# Altium schematic sheet Main.SchDoc(Main)
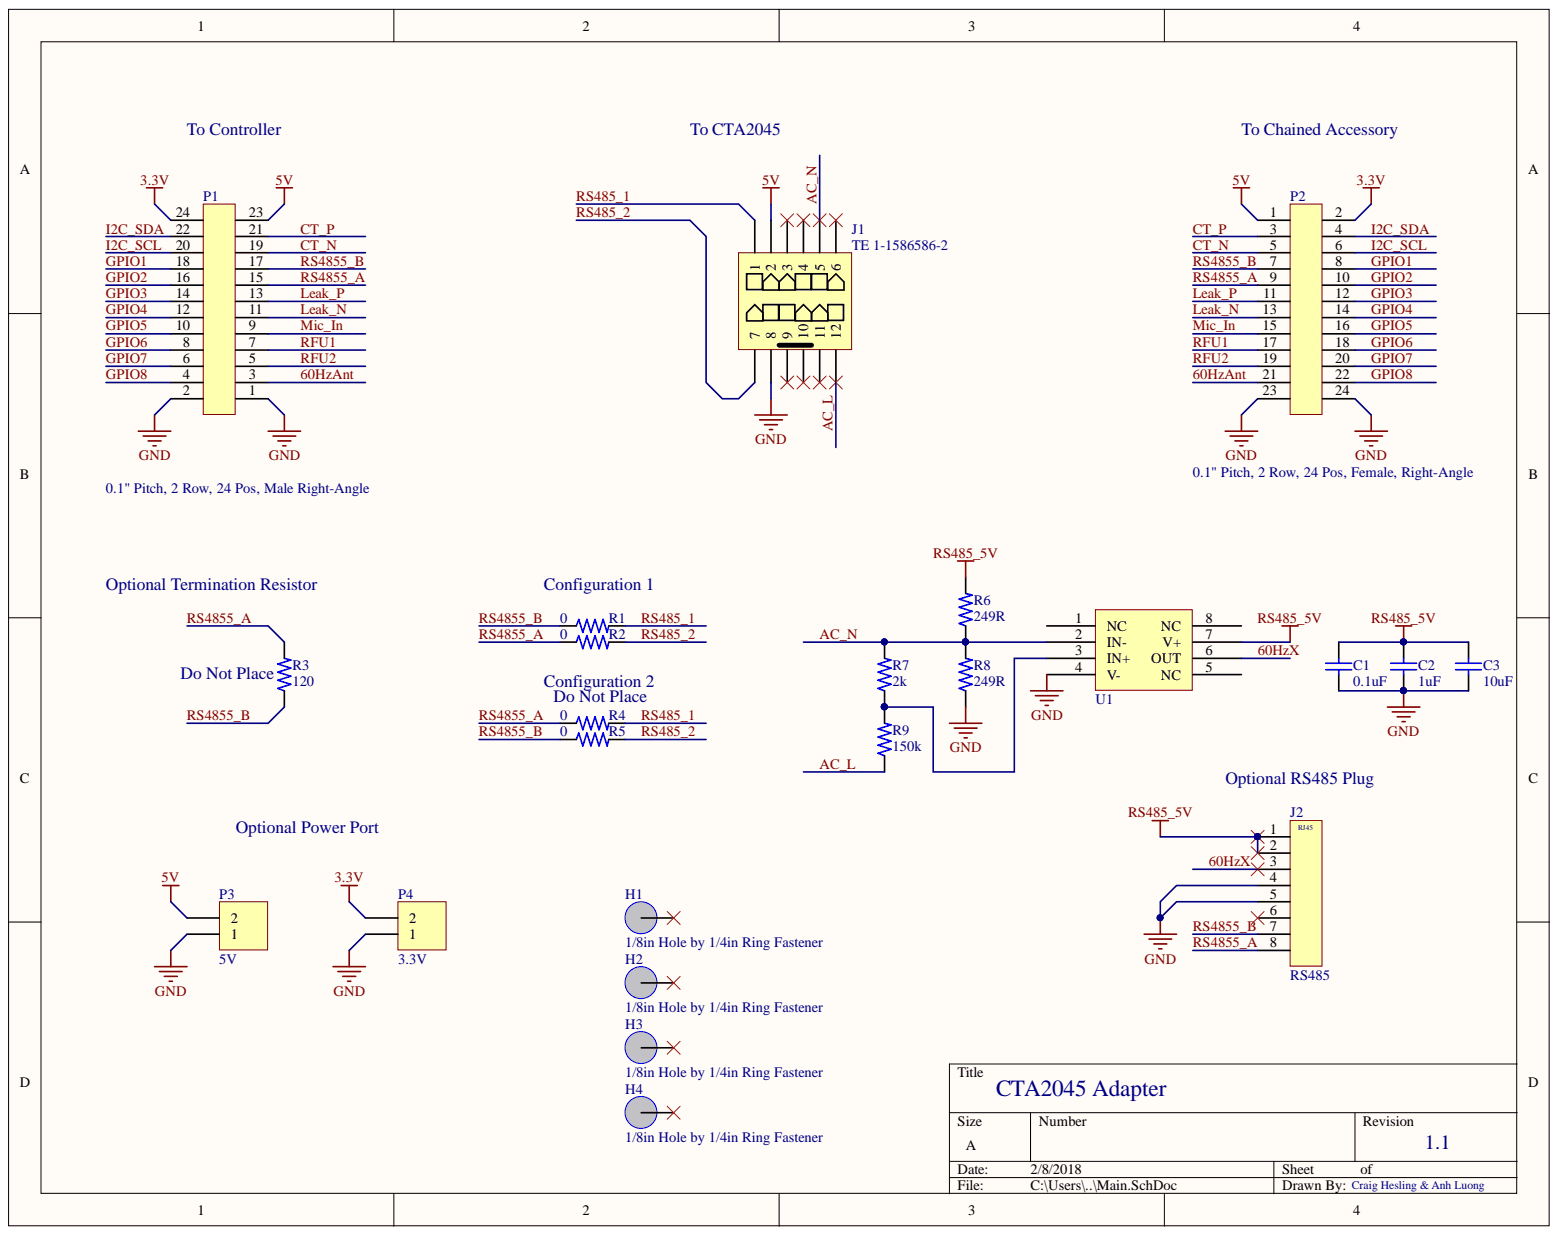
[diagram p0: Main.SchDoc]

## Parts
C1  0.1uF  [CAPC1608X09L - CAP0603]
C2  1uF  [CAPC1608X09L - CAP0603]
C3  10uF  [CAPC1608X09L - CAP0603]
H1  1/8in Hole by 1/4in Ring Fastener  [1/8in Fastener Hole]
H2  1/8in Hole by 1/4in Ring Fastener  [1/8in Fastener Hole]
H3  1/8in Hole by 1/4in Ring Fastener  [1/8in Fastener Hole]
H4  1/8in Hole by 1/4in Ring Fastener  [1/8in Fastener Hole]
J1  TE 1-1586586-2  [12POS 4.2mm Pitch Vertical]
J2  RS485  Connector Type: RJ45  [RJ45 Unshielded PTH Connector]
P1  0.1" Pitch, 2 Row, 24 Pos, Male Right-Angle  [0.1 inch 2 row 24 pos male right-angle header Sullins]
P2  0.1" Pitch, 2 Row, 24 Pos, Female, Right-Angle  [0.1 inch 2 row 24 pos female right-angle header Sullins]
P3  5V  [HDR1X2]
P4  3.3V  [HDR1X2]
R1  0  [RESC1608X06L - RES0603]
R2  0  [RESC1608X06L - RES0603]
R3  120  [RESC1608X06L - RES0603]
R4  0  [RESC1608X06L - RES0603]
R5  0  [RESC1608X06L - RES0603]
R6  249R  [RESC1608X06L - RES0603]
R7  2k  [RESC3116X06N]
R8  249R  [RESC1608X06L - RES0603]
R9  150k  [RESC3116X06N]
U1  LT1818IS8#PBF  [SOIC127P600X175-8N]  (value not drawn)

## Nets
3.3V: P1.24 P2.2 P4.2
5V: J1.2 P1.23 P2.1 P3.2
GND: C1.1 C2.1 C3.1 J1.8 J2.4 J2.5 P1.1 P1.2 P2.23 P2.24 P3.1 P4.1 R8.1 U1.4(V-)
RS485_5V: C1.2 C2.2 C3.2 J2.1 J2.2 R6.2 U1.7(V+)
60HzAnt: P1.3 P2.21
60HzX: J2.3 U1.6(OUT)
AC_L: J1.12 R9.1
AC_N: J1.5 R6.1 R7.2 R8.2 U1.2(IN-)
CT_N: P1.19 P2.5
CT_P: P1.21 P2.3
GPIO1: P1.18 P2.8
GPIO2: P1.16 P2.10
GPIO3: P1.14 P2.12
GPIO4: P1.12 P2.14
GPIO5: P1.10 P2.16
GPIO6: P1.8 P2.18
GPIO7: P1.6 P2.20
GPIO8: P1.4 P2.22
I2C_SCL: P1.20 P2.6
I2C_SDA: P1.22 P2.4
Leak_N: P1.11 P2.13
Leak_P: P1.13 P2.11
Mic_In: P1.9 P2.15
RFU1: P1.7 P2.17
RFU2: P1.5 P2.19
RS485_1: J1.1 R1.2 R4.2
RS485_2: J1.7 R2.2 R5.2
RS4855_A: J2.8 P1.15 P2.9 R2.1 R3.2 R4.1
RS4855_B: J2.7 P1.17 P2.7 R1.1 R3.1 R5.1
NetR7_1: R7.1 R9.2 U1.3(IN+)

Not connected: H1 1; H2 1; H3 1; H4 1; J1 3 4 6 9 10 11; J2 6; U1 1(NC) 5(NC) 8(NC)

## Library data of the parts
C1 = CAP0603 0.1uF 10% 25V X7R: SMT 0603 Ceramic Capacitor; Voltage: 25V; Tolerance: 10%; TempCoeff: X7R; Value: 0.1uF; Supplier Part Number 2: 490-1524-1-ND; Supplier Part Number 1: 311-1341-1-ND; Supplier 2: Digi-Key; Supplier 1: Digi-Key; Library Name: Passives.SchLib; Component Kind: Standard
C2 = CAP0603 1uF 10% 10V X7R: SMT 0603 Ceramic Capacitor; Voltage: 10V; Tolerance: 10%; TempCoeff: X7R; Value: 1uF; Supplier Part Number 2: 399-3118-1-ND; Supplier Part Number 1: 1276-1946-1-ND; Supplier 2: Digi-Key; Supplier 1: Digi-Key; Library Name: Passives.SchLib; Component Kind: Standard
C3 = CAP0603 10uF 20% 6.3V X5R: SMT 0603 Ceramic Capacitor; Voltage: 6.3V; Tolerance: 20%; TempCoeff: X5R; Value: 10uF; Supplier Part Number 2: 490-3896-1-ND; Supplier Part Number 1: 587-1256-1-ND; Supplier 2: Digi-Key; Supplier 1: Digi-Key; Library Name: Passives.SchLib; Component Kind: Standard
H1-H4 = 1/8in by 1/4in Fastner Hole: Library Name: Misc.SchLib; Component Kind: Standard
J1 = 12POS 4.2mm Pitch Vertical: TE Connectivity 1-1586586-2; Supplier Part Number 2: 1-1586586-2-ND; Supplier Part Number 1: 70041598; Supplier 2: Digi-Key; Supplier 1: Allied; Library Name: Connectors.SchLib; Component Kind: Standard
J2 = RJ45 Unshielded PTH Connector: Amphenol RJ45 Unshielded Through-Hole Connector; Manufacturer Part Number: 54601-908WPLF; Manufacturer: Amphenol FCI; Voltage Rating: 1kV; Pitch: 2.54mm; Number of Positions Loaded: 8; Number of Ports: 1; Insulation Resistance: 500MR; Gender: Male; Direction: Down; Current Rating: 1A; Contact Resistance: 30mR; Termination: Through Hole; Supplier Part Number 2: 649-54601-908WPLF; Supplier Part Number 1: 609-5081-ND; Supplier 2: Mouser; Supplier 1: Digi-Key; Stock 2: 0; Shielding: Unshielded; REACH SVHC: No SVHC; Radiation Hardening: No; Pricing 2: 1=0.51, 10=0.377, 50=0.377, 100=0.362, 1000=0.26, 10000=0.212 (USD); Packaging: Rail/Tube; Package Quantity: 200; Orientation: Right Angle; Number of Rows: 1; Number of Positions: 8; Number of Contacts: 8; Mount: Through Hole; Min Operating Temperature: -65°C; Max Operating Temperature: 125°C; Library Name: Connectors.SchLib; Length: 15.24mm; Lead Free: Lead Free; Housing Material: Polyamide; Housing Colour: Black; Flammability Rating: UL94 V-0; Depth: 18mm; Contact Plating: Nickel,Gold; Contact Material: Bronze,Copper; ComponentLink6URL: https://datasheet.ciiva.com/7629/c-bmj-0051-7629183.pdf; ComponentLink6Description: https://datasheet.ciiva.com/7629/c-bmj-0051-7629183.pdf; ComponentLink5URL: https://datasheet.ciiva.com/16650/c-bmj-0051-16650286.pdf; ComponentLink5Description: https://datasheet.ciiva.com/16650/c-bmj-0051-16650286.pdf; ComponentLink4URL: https://datasheet.ciiva.com/15058/c-bmj-0051-15058750.pdf; ComponentLink4Description: https://datasheet.ciiva.com/15058/c-bmj-0051-15058750.pdf; ComponentLink3URL: https://datasheet.ciiva.com/1108/c-bmj-0051-1108424.pdf; ComponentLink3Description: https://datasheet.ciiva.com/1108/c-bmj-0051-1108424.pdf; ComponentLink2URL: https://datasheet.ciiva.com/26824/getdatasheetpartid-465238-26824373.pdf; ComponentLink2Description: https://datasheet.ciiva.com/26824/getdatasheetpartid-465238-26824373.pdf; ComponentLink1URL: https://datasheet.ciiva.com/9396/c-bmj-0051-9396388.pdf; ComponentLink1Description: https://datasheet.ciiva.com/9396/c-bmj-0051-9396388.pdf; Component Kind: Standard; Colour: Black
P1 = 0.1" 2 row 24 position male right-angle header sullins: Sullins 0.1" 2 row 24 position male right-angle header; Rows: 2; Positions: 24; Pitch: 2.54mm; Supplier Part Number 1: S2112EC-12-ND; Supplier 1: Digi-Key; Library Name: Connectors.SchLib; Gender: Male; Component Kind: Standard
P2 = 0.1" 2 row 24 position female right-angle header sullins: Sullins 0.1" 2 row 24 position female right-angle header; Rows: 2; Positions: 24; Pitch: 2.54mm; Supplier Part Number 2: S5565-ND; Supplier Part Number 1: S5526-ND; Supplier 2: Digi-Key; Supplier 1: Digi-Key; Library Name: Connectors.SchLib; Component Kind: Standard
P3 P4 = Header 2: Header, 2-Pin; Signal Integrity: Connector; Library Name: Miscellaneous Connectors.IntLib; LatestRevisionNote: Re-released for DXP Platform.; LatestRevisionDate: 17-Jul-2002; Component Kind: Standard; Publisher: Altium Limited
R1 R2 R4 R5 = RES0603 0 Jumper 1/10W: SMT 0603 Ceramic Resistor; Tolerance: Jumper; Power: 1/10W; Value: 0; Supplier Part Number 2: P0.0GCT-ND; Supplier Part Number 1: 311-0.0GRCT-ND; Supplier 2: Digi-Key; Supplier 1: Digi-Key; Library Name: Passives.SchLib; Component Kind: Standard
R3 = RES0603 120 1% 1/10W: SMT 0603 Ceramic Resistor; Value: 120; Supplier Part Number 3: YAG3563CT-ND; Supplier Part Number 2: RMCF0603FT120RCT-ND; Supplier Part Number 1: 311-120HRCT-ND; Supplier 3: Digi-Key; Supplier 2: Digi-Key; Supplier 1: Digi-Key; Library Name: Passives.SchLib; Component Kind: Standard
R6 R8 = RC0603FR-07249RL: RES SMD 249 OHM 1% 1/10W 0603; Manufacturer: Yageo; Voltage Rating (DC): 50V; Temperature Coefficient: 100ppm/°C; Power Rating: 100mW; Width: 0.031inch; Voltage Rating: 50V; Tolerance: 1%; Termination: SMD/SMT; Supplier Part Number 1: 311-249HRDKR-ND; Supplier 1: Digi-Key; Stock 1: 517619; Resistance: 249R; Pricing 1: 1=0.1, 10=0.015, 100=0.006, 1000=0.00268, 2500=0.00233 (USD); Packaging: Tape and Reel; Package Quantity: 1; Number of Terminations: 2; Min Operating Temperature: -55°C; Military Standard: Not; Max Power Dissipation: 100mW; Max Operating Temperature: 155°C; Manufacturer Part Number: RC0603FR-07249RL; Library Name: Passives.SchLib; Length: 0.063inch; Lead Free: Lead Free; Height: 0.022inch; Depth: 0.8mm; Composition: Thick Film; ComponentLink3URL: https://datasheet.ciiva.com/2454/pyu-r-int-thick-7-2454860.pdf; ComponentLink3Description: https://datasheet.ciiva.com/2454/pyu-r-int-thick-7-2454860.pdf; ComponentLink2URL: https://datasheet.ciiva.com/2454/pyu-r-int-thick-7-2454859.pdf; ComponentLink2Description: https://datasheet.ciiva.com/2454/pyu-r-int-thick-7-2454859.pdf; ComponentLink1URL: https://datasheet.ciiva.com/2454/pyu-r-int-thick-7-2454858.pdf; ComponentLink1Description: https://datasheet.ciiva.com/2454/pyu-r-int-thick-7-2454858.pdf; Component Kind: Standard; Case Code (Metric): 1608; Case Code (Imperial): 0603; Case/Package: 0603
R7 = RC1206FR-072KL: Yageo RC1206 Series Thick Film Surface Mount Resistor 1206 Case 2kO ±1% 0.25W ±100ppm/°C; Voltage Rating (DC): 200V; Resistance: 2kR; Power Rating: 250mW; Width: 1.6mm; Voltage Rating: 200V; Tolerance: 1%; Termination: SMD/SMT; Temperature Coefficient: 100ppm/°C; Supplier Part Number 1: 311-2.00KFRDKR-ND; Supplier 1: Digi-Key; Stock 1: 842802; Pricing 1: 1=0.1, 10=0.033, 100=0.0136, 1000=0.0061, 2500=0.00529 (USD); Packaging: Tape and Reel; Mount: Surface Mount; Min Operating Temperature: -55°C; Military Standard: Not; Max Operating Temperature: 155°C; Manufacturer Part Number: RC1206FR-072KL; Manufacturer: Yageo; Library Name: Passives.SchLib; Length: 3.1mm; Lead Free: Lead Free; Height: 0.55mm; Depth: 1.6mm; Composition: Thick Film; ComponentLink2URL: https://datasheet.ciiva.com/16740/pyu-rc1206-51-rohs-l-4-16740842.pdf; ComponentLink2Description: https://datasheet.ciiva.com/16740/pyu-rc1206-51-rohs-l-4-16740842.pdf; ComponentLink1URL: https://datasheet.ciiva.com/11808/0900766b80c6630a-11808949.pdf; ComponentLink1Description: https://datasheet.ciiva.com/11808/0900766b80c6630a-11808949.pdf; Component Kind: Standard; Case Code (Metric): 3216; Case Code (Imperial): 1206; Case/Package: 1206
R9 = RC1206FR-07150KL: RES SMD 150K OHM 1% 1/4W 1206; Manufacturer: Yageo; Voltage Rating: 200V; Temperature Coefficient: 100ppm/°C; Resistance: 150kR; Power Rating: 250mW; Width: 1.6mm; Tolerance: 1%; Termination: SMD/SMT; Supplier Part Number 1: 311-150KFRDKR-ND; Supplier 1: Digi-Key; Stock 1: 362280; REACH SVHC: Unknown; Pricing 1: 1=0.1, 10=0.033, 100=0.0136, 1000=0.0061, 2500=0.00529 (USD); Packaging: Tape and Reel; Package Quantity: 1; Number of Terminations: 2; Min Operating Temperature: -55°C; Max Power Dissipation: 250mW; Max Operating Temperature: 155°C; Manufacturer Part Number: RC1206FR-07150KL; Library Name: Passives.SchLib; Length: 3.1mm; Lead Free: Lead Free; Height: 0.55mm; Depth: 1.6mm; Composition: Thick Film; ComponentLink9URL: https://datasheet.ciiva.com/29181/402800-da-01-en-smd-widerstand-chip1206-150-kohm-29181403.pdf; ComponentLink9Description: https://datasheet.ciiva.com/29181/402800-da-01-en-smd-widerstand-chip1206-150-kohm-29181403.pdf; ComponentLink8URL: https://datasheet.ciiva.com/16769/pyu-rc1206-51-rohs-l-4-16769727.pdf; ComponentLink8Description: https://datasheet.ciiva.com/16769/pyu-rc1206-51-rohs-l-4-16769727.pdf; ComponentLink7URL: https://datasheet.ciiva.com/11809/0900766b80c6630a-11809439.pdf; ComponentLink7Description: https://datasheet.ciiva.com/11809/0900766b80c6630a-11809439.pdf; ComponentLink6URL: https://datasheet.ciiva.com/2521/pyu-rc1206-51-rohs-l-4-2521087.pdf; ComponentLink6Description: https://datasheet.ciiva.com/2521/pyu-rc1206-51-rohs-l-4-2521087.pdf; ComponentLink5URL: https://datasheet.ciiva.com/2521/pyu-rc1206-51-rohs-l-4-2521086.pdf; ComponentLink5Description: https://datasheet.ciiva.com/2521/pyu-rc1206-51-rohs-l-4-2521086.pdf; ComponentLink4URL: https://datasheet.ciiva.com/2521/pyu-rc1206-51-rohs-l-4-2521085.pdf; ComponentLink4Description: https://datasheet.ciiva.com/2521/pyu-rc1206-51-rohs-l-4-2521085.pdf; ComponentLink3URL: https://datasheet.ciiva.com/7857/444357-7857259.pdf; ComponentLink3Description: https://datasheet.ciiva.com/7857/444357-7857259.pdf; ComponentLink2URL: https://datasheet.ciiva.com/7857/444357-7857258.pdf; ComponentLink2Description: https://datasheet.ciiva.com/7857/444357-7857258.pdf; ComponentLink1URL: https://datasheet.ciiva.com/46445/444357-46445705.pdf; ComponentLink1Description: https://datasheet.ciiva.com/46445/444357-46445705.pdf; Component Kind: Standard; Case Code (Metric): 3216; Case Code (Imperial): 1206; Case/Package: 1206
U1 = LT1818IS8#PBF: IC OPAMP VFB 400MHZ 8SO; Manufacturer: Linear Technology; Voltage Gain: 72.04dB; Unity Gain Bandwidth Product: 360MHz; Slew Rate: 2500V/µs; Power Supply Rejection Ratio (PSRR): 78dB; Output Current per Channel: 70mA; Operating Supply Current: 9mA; Number of Elements: 1; Min Supply Voltage: 3.5V; Min Dual Supply Voltage: 1.75V; Max Supply Voltage: 12.6V; Max Dual Supply Voltage: 6.3V; Input Offset Voltage (Vos): 1.5mV; Input Bias Current: 2uA; Common Mode Rejection Ratio: 73dB; Supplier Part Number 1: LT1818IS8#PBF-ND; Supplier 1: Digi-Key; Stock 1: 576; Pricing 1: 1=2.36, 25=1.6728, 100=1.377 (USD); Packaging: Rail/Tube; Package Quantity: 100; Number of Pins: 8; Number of Circuits: 1; Number of Channels: 1; Nominal Gain Bandwidth Product: 400MHz; Min Operating Temperature: -40°C; Max Operating Temperature: 85°C; Manufacturer Part Number: LT1818IS8#PBF; Lead Free: Lead Free; Gain Bandwidth Product: 400MHz; ComponentLink1URL: https://datasheet.ciiva.com/1805/1191-1805828.pdf; ComponentLink1Description: https://datasheet.ciiva.com/1805/1191-1805828.pdf; Component Kind: Standard; Case/Package: SOIC; Library Name: Actives.SchLib
